# Altium schematic sheet I2C-AI418M.SchDoc
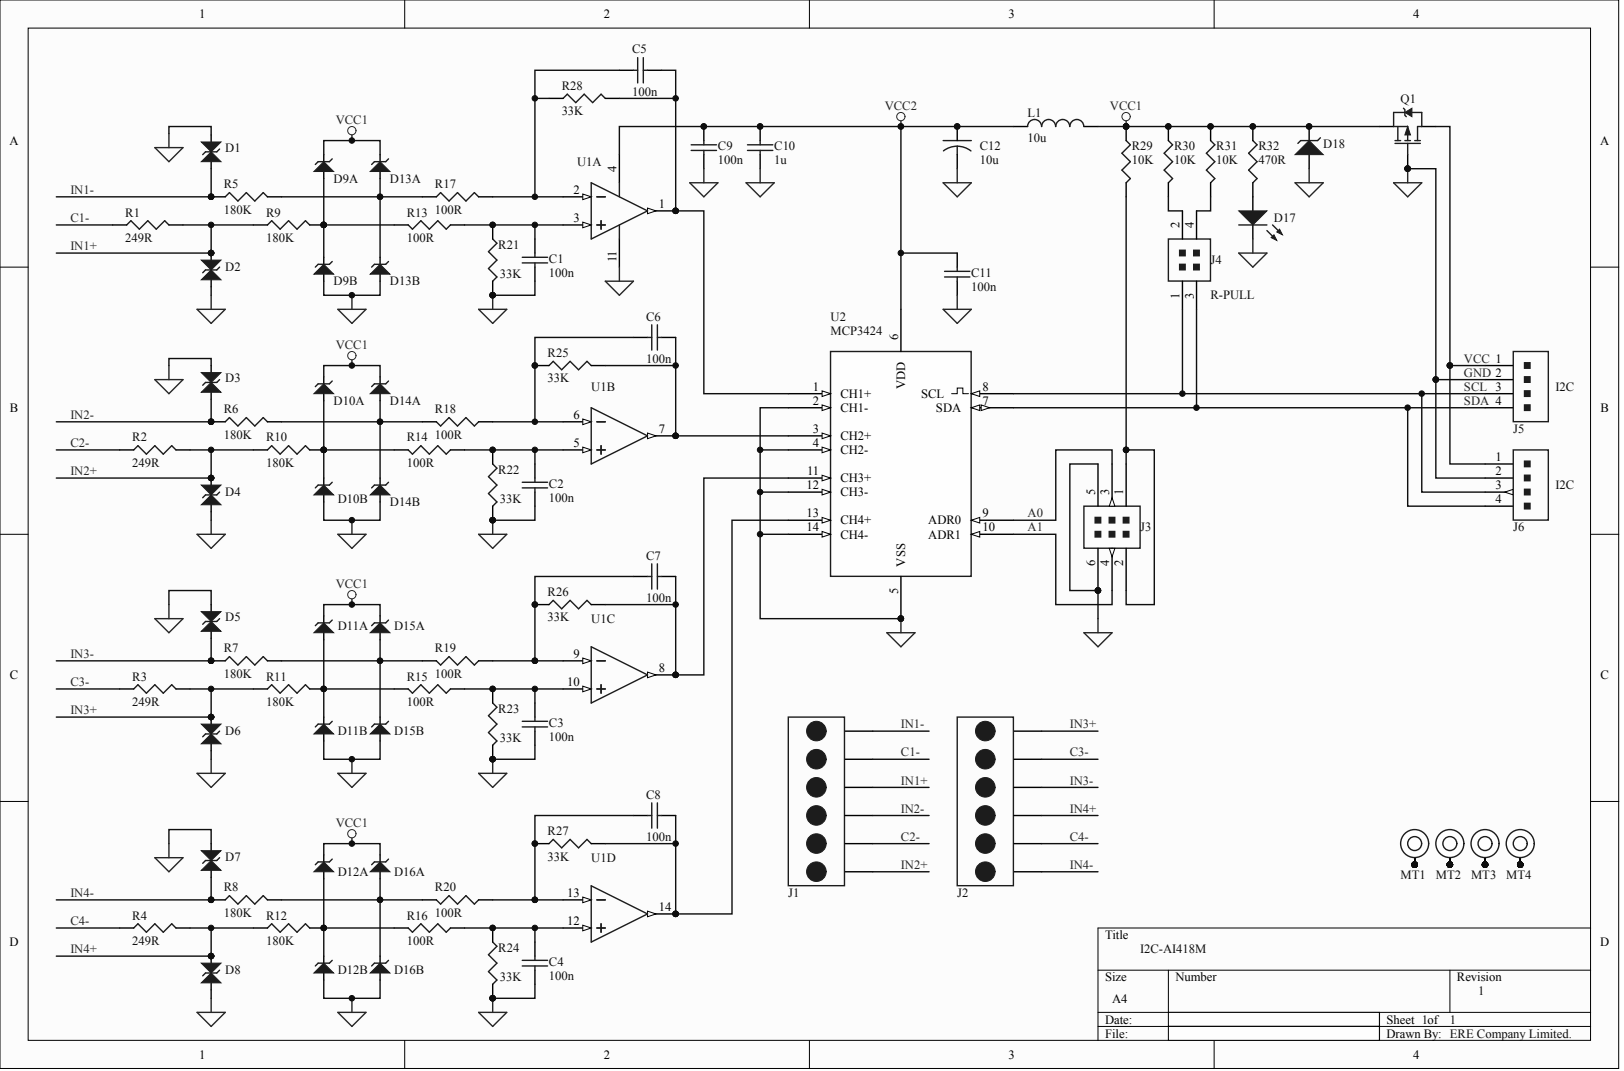
[diagram p0: I2C-AI418M.SchDoc]

## Parts
C1  value 100n  (hidden comment: GRM1881H104)
C2  value 100n  (hidden comment: GRM1881H104)
C3  value 100n  (hidden comment: GRM1881H104)
C4  value 100n  (hidden comment: GRM1881H104)
C5  value 100n  (hidden comment: GRM1881H104)
C6  value 100n  (hidden comment: GRM1881H104)
C7  value 100n  (hidden comment: GRM1881H104)
C8  value 100n  (hidden comment: GRM1881H104)
C9  value 100n  (hidden comment: GRM1881H104)
C10  value 1u  (hidden comment: GRM21B1E105Z)
C11  value 100n  (hidden comment: GRM1881H104)
C12  value 10u  (hidden comment: T491B106K010ZT)
D1  SMAJ30CA  (value not drawn)
D2  SMAJ30CA  (value not drawn)
D3  SMAJ30CA  (value not drawn)
D4  SMAJ30CA  (value not drawn)
D5  SMAJ30CA  (value not drawn)
D6  SMAJ30CA  (value not drawn)
D7  SMAJ30CA  (value not drawn)
D8  SMAJ30CA  (value not drawn)
D9  BAS70-04  (value not drawn)  (parts A,B)
D10  BAS70-04  (value not drawn)  (parts A,B)
D11  BAS70-04  (value not drawn)  (parts A,B)
D12  BAS70-04  (value not drawn)  (parts A,B)
D13  BAS70-04  (value not drawn)  (parts A,B)
D14  BAS70-04  (value not drawn)  (parts A,B)
D15  BAS70-04  (value not drawn)  (parts A,B)
D16  BAS70-04  (value not drawn)  (parts A,B)
D17  LTST-C150EKT  (value not drawn)
D18  TZM5232B  (value not drawn)
J1  DT-126VP-06P  (value not drawn)
J2  DT-126VP-06P  (value not drawn)
J3  PHDS06G22  (value not drawn)
J4  PHDS04G22  (value not drawn)
J5  112504ST  (value not drawn)
J6  112504ST  (value not drawn)
L1  value 10u  (hidden comment: ISC1210ER100K)
MT1  MTGNP710H360V6B  (value not drawn)
MT2  MTGNP710H360V6B  (value not drawn)
MT3  MTGNP710H360V6B  (value not drawn)
MT4  MTGNP710H360V6B  (value not drawn)
Q1  FDN306P  (value not drawn)
R1  value 249R  (hidden comment: MCR25F2490)
R2  value 249R  (hidden comment: MCR25F2490)
R3  value 249R  (hidden comment: MCR25F2490)
R4  value 249R  (hidden comment: MCR25F2490)
R5  value 180K  (hidden comment: MCR03F1652)
R6  value 180K  (hidden comment: MCR03F1652)
R7  value 180K  (hidden comment: MCR03F1652)
R8  value 180K  (hidden comment: MCR03F1652)
R9  value 180K  (hidden comment: MCR03F1652)
R10  value 180K  (hidden comment: MCR03F1652)
R11  value 180K  (hidden comment: MCR03F1652)
R12  value 180K  (hidden comment: MCR03F1652)
R13  value 100R  (hidden comment: MCR03F1000)
R14  value 100R  (hidden comment: MCR03F1000)
R15  value 100R  (hidden comment: MCR03F1000)
R16  value 100R  (hidden comment: MCR03F1000)
R17  value 100R  (hidden comment: MCR03F1000)
R18  value 100R  (hidden comment: MCR03F1000)
R19  value 100R  (hidden comment: MCR03F1000)
R20  value 100R  (hidden comment: MCR03F1000)
R21  value 33K  (hidden comment: MCR03F3302)
R22  value 33K  (hidden comment: MCR03F3302)
R23  value 33K  (hidden comment: MCR03F3302)
R24  value 33K  (hidden comment: MCR03F3302)
R25  value 33K  (hidden comment: MCR03F3302)
R26  value 33K  (hidden comment: MCR03F3302)
R27  value 33K  (hidden comment: MCR03F3302)
R28  value 33K  (hidden comment: MCR03F3302)
R29  value 10K  (hidden comment: MCR03F1002)
R30  value 10K  (hidden comment: MCR03F1002)
R31  value 10K  (hidden comment: MCR03F1002)
R32  value 470R  (hidden comment: MCR03F4700)
U1  MCP6004  (value not drawn)  (parts A,B,C,D)
U2  MCP3424

## Nets
VCC1: D9.2 D10.2 D11.2 D12.2 D13.2 D14.2 D15.2 D16.2 D18.1 L1.2 Q1.2 R29.1 R30.1 R31.1 R32.1
VCC2: C9.1 C10.1 C11.1 C12.2 L1.1 U1.4 U2.6(VDD)
A0: J3.3 U2.9(ADR0)
A1: J3.4 U2.10(ADR1)
C1-: J1.5 R1.1
C2-: J1.2 R2.1
C3-: J2.5 R3.1
C4-: J2.2 R4.1
GND: C1.2 C2.2 C3.2 C4.2 C9.2 C10.2 C11.2 C12.1 D1.1 D2.2 D3.1 D4.2 D5.1 D6.2 D7.1 D8.2 D9.1 D10.1 D11.1 D12.1 D13.1 D14.1 D15.1 D16.1 D17.1 D18.2 J3.5 J3.6 J5.2 J6.2 Q1.1 R21.2 R22.2 R23.2 R24.2 U1.11 U2.2(CH1-) U2.4(CH2-) U2.5(VSS) U2.12(CH3-) U2.14(CH4-)
IN1+: D2.1 J1.4 R1.2 R9.1
IN1-: D1.2 J1.6 R5.1
IN2+: D4.1 J1.1 R2.2 R10.1
IN2-: D3.2 J1.3 R6.1
IN3+: D6.1 J2.6 R3.2 R11.1
IN3-: D5.2 J2.4 R7.1
IN4+: D8.1 J2.3 R4.2 R12.1
IN4-: D7.2 J2.1 R8.1
SCL: J4.1 J5.3 J6.3 U2.8
SDA: J4.3 J5.4 J6.4 U2.7(SDA)
VCC: J5.1 J6.1 Q1.3
NetC1_1: C1.1 R13.1 R21.1 U1.3
NetC2_1: C2.1 R14.1 R22.1 U1.5
NetC3_1: C3.1 R15.1 R23.1 U1.10
NetC4_1: C4.1 R16.1 R24.1 U1.12
NetC5_1: C5.1 R17.2 R28.1 U1.2
NetC5_2: C5.2 R28.2 U1.1 U2.1(CH1+)
NetC6_1: C6.1 R18.1 R25.1 U1.6
NetC6_2: C6.2 R25.2 U1.7 U2.3(CH2+)
NetC7_1: C7.1 R19.1 R26.1 U1.9
NetC7_2: C7.2 R26.2 U1.8 U2.11(CH3+)
NetC8_1: C8.1 R20.1 R27.1 U1.13
NetC8_2: C8.2 R27.2 U1.14 U2.13(CH4+)
NetD9_3: D9.3 R9.2 R13.2
NetD10_3: D10.3 R10.2 R14.2
NetD11_3: D11.3 R11.2 R15.2
NetD12_3: D12.3 R12.2 R16.2
NetD13_3: D13.3 R5.2 R17.1
NetD14_3: D14.3 R6.2 R18.2
NetD15_3: D15.3 R7.2 R19.2
NetD16_3: D16.3 R8.2 R20.2
NetD17_2: D17.2 R32.2
NetJ3_1: J3.1 J3.2 R29.2
NetJ4_2: J4.2 R30.2
NetJ4_4: J4.4 R31.2

Not connected: MT1 1; MT2 1; MT3 1; MT4 1

## Library data of the parts
C1-C9 C11 = (no symbol name): Volatge: 50V
C10 = (no symbol name): Volatge: 25V
C12 = (no symbol name): Voltage: 10V
D17 = (no symbol name): Color: RD
R1-R4 = (no symbol name): Tolerance: 1%; Power: 0.5W
R5-R32 = (no symbol name): Power: 0.1W; Tolerance: 1%
